# Altium schematic sheet bluetooth.SchDoc(bluetooth)
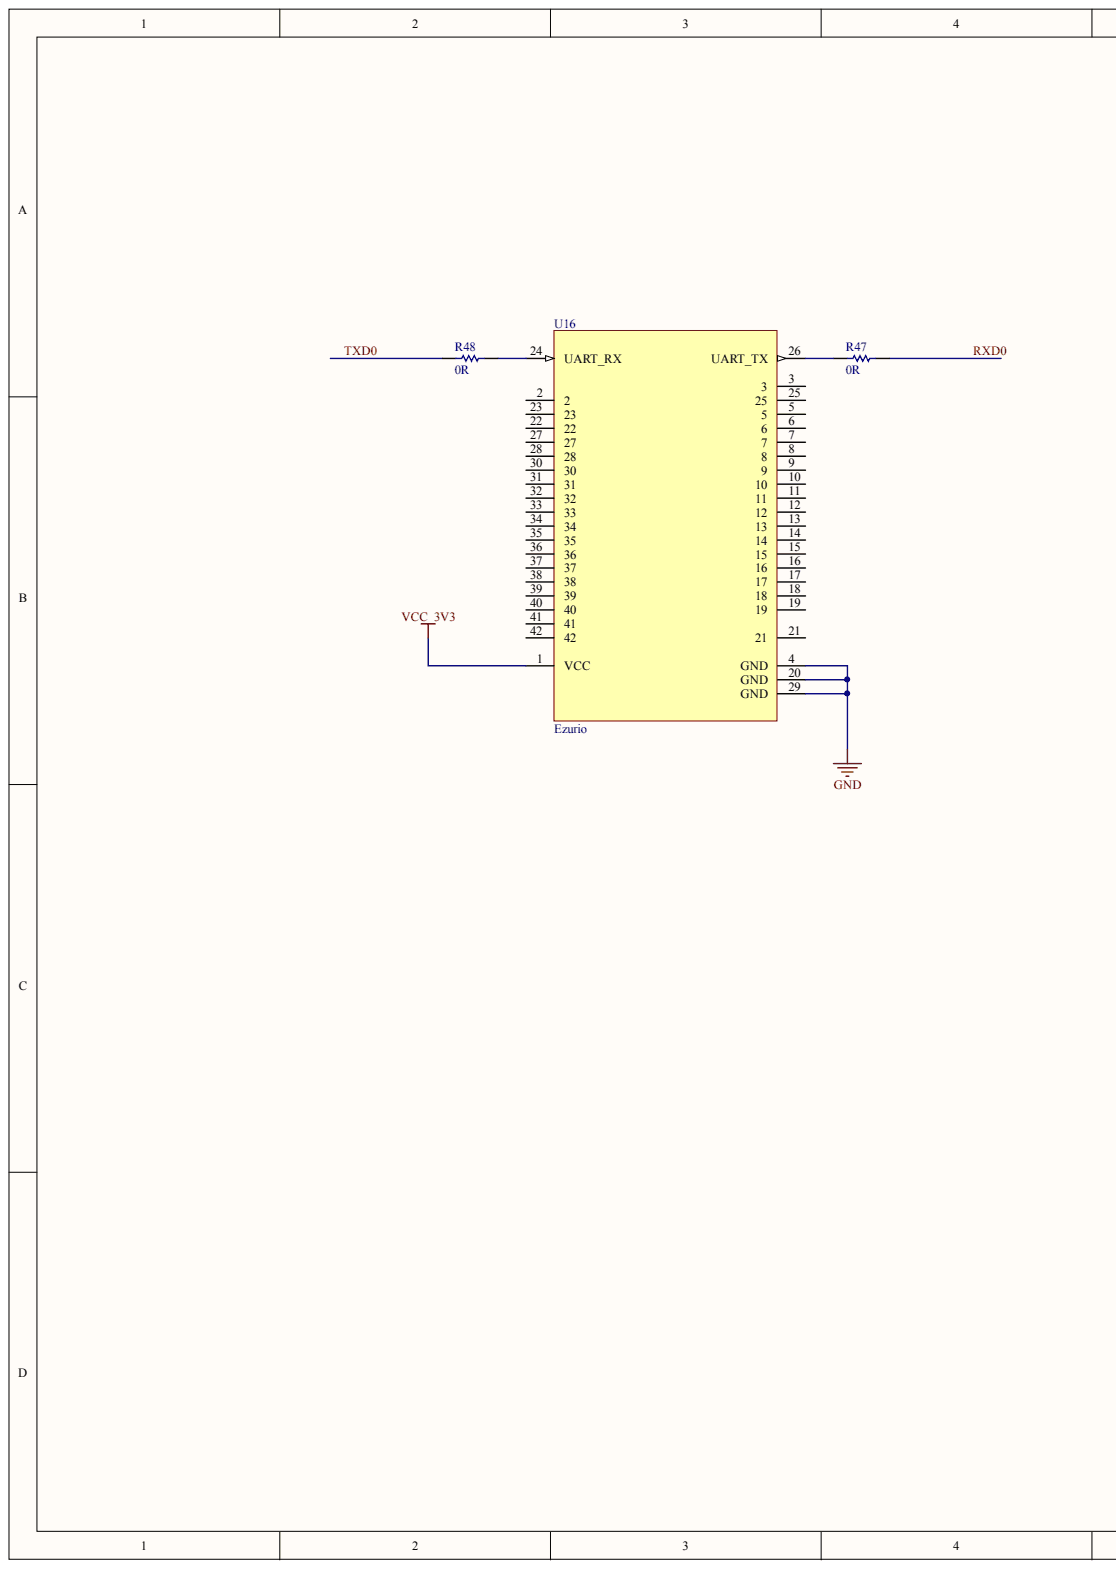
[diagram p0: bluetooth.SchDoc, left]
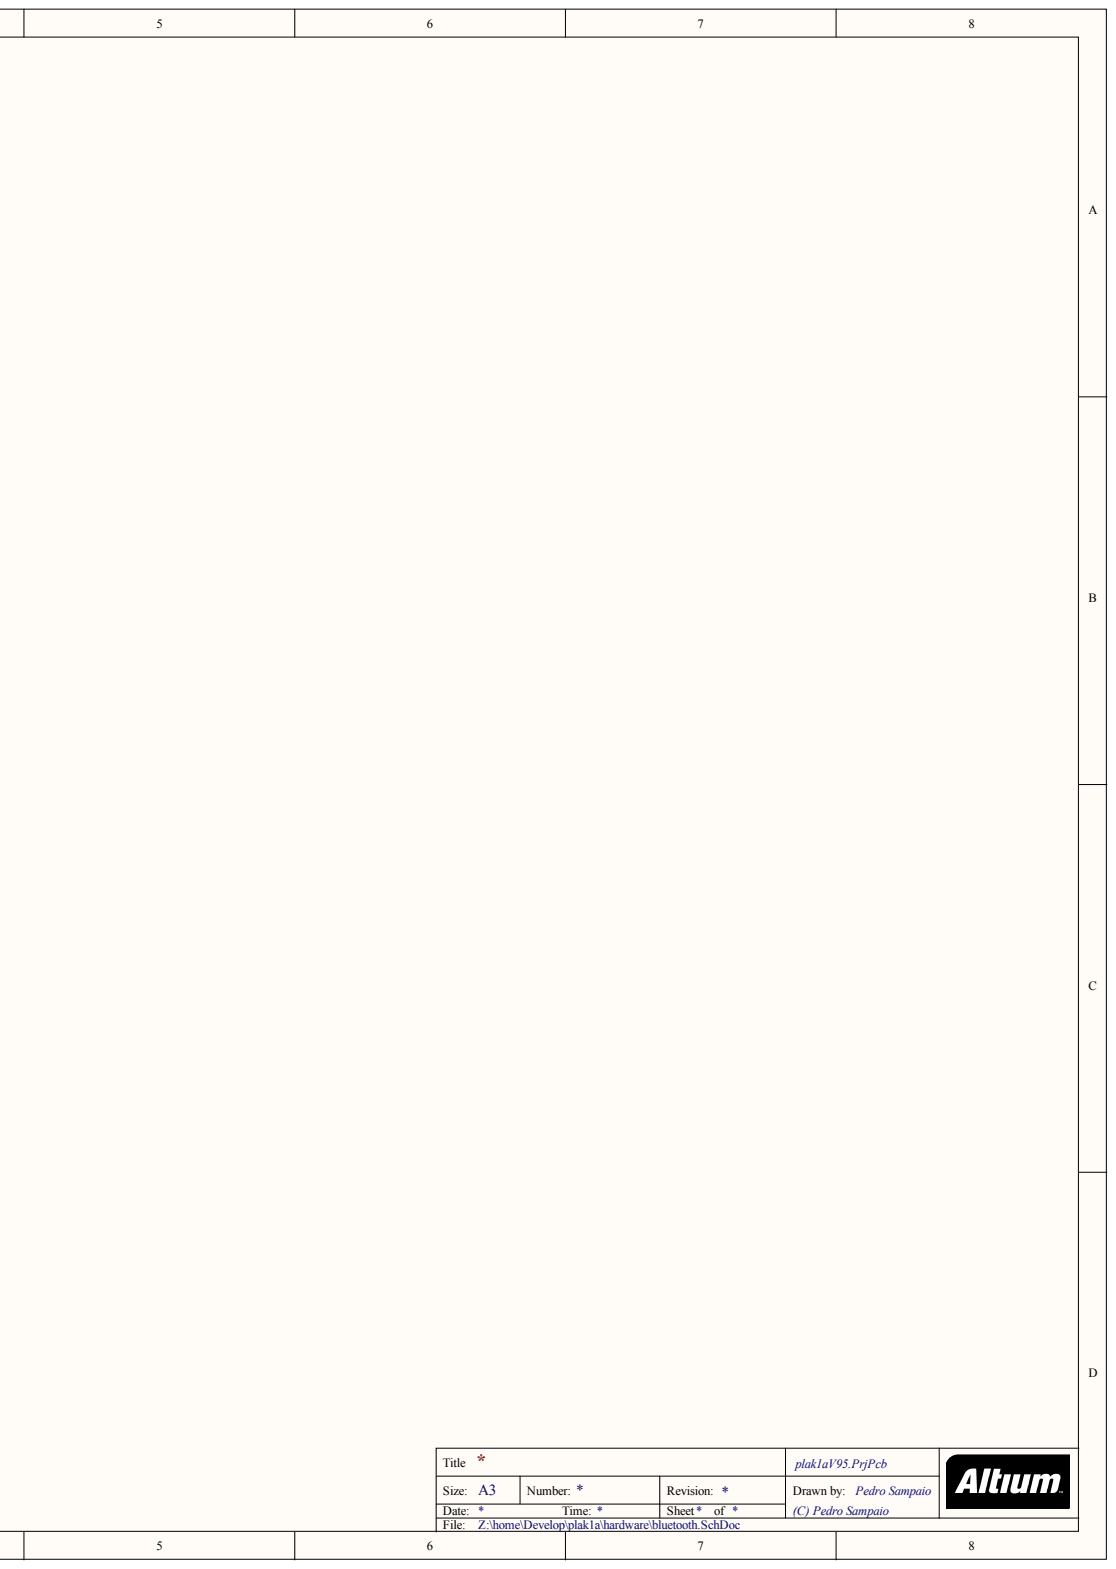
[diagram p1: bluetooth.SchDoc, right]

## Parts
R47  value 0R  [2012[0805]]  (hidden comment: Res Semi)
R48  value 0R  [2012[0805]]  (hidden comment: Res Semi)
U16  Ezurio  [SOP150P1900X500-60N]

## Nets
GND: U16.4(GND) U16.20(GND) U16.29(GND)
VCC_3V3: U16.1(VCC)
RXD0: R47.2
TXD0: R48.1
NetR47_1: R47.1 U16.26(UART_TX)
NetR48_2: R48.2 U16.24(UART_RX)

Not connected: U16 2 3 5 6 7 8 9 10 11 12 13 14 15 16 17 18 19 21 22 23 25 27 28 30 31 32 33 34 35 36 37 38 39 40 41 42

## Library data of the parts
R47 R48 = Res Semi: Semiconductor Resistor; Component Kind: Standard; Farnell: 9240489; LatestRevisionDate: 17-Jul-2002; LatestRevisionNote: Re-released for DXP Platform.; Library Name: Miscellaneous Devices.IntLib; PackageReference: AXIAL-0.5; Published: 8-Jun-2000; Signal Integrity: Res Semi; Simulation: RES; Publisher: Altium Limited
U16 = Ezurio: Bluetooth Module; Component Kind: Standard; Library Name: Componentes.SchLib
